# Altium schematic sheet Master.SchDoc(Master)
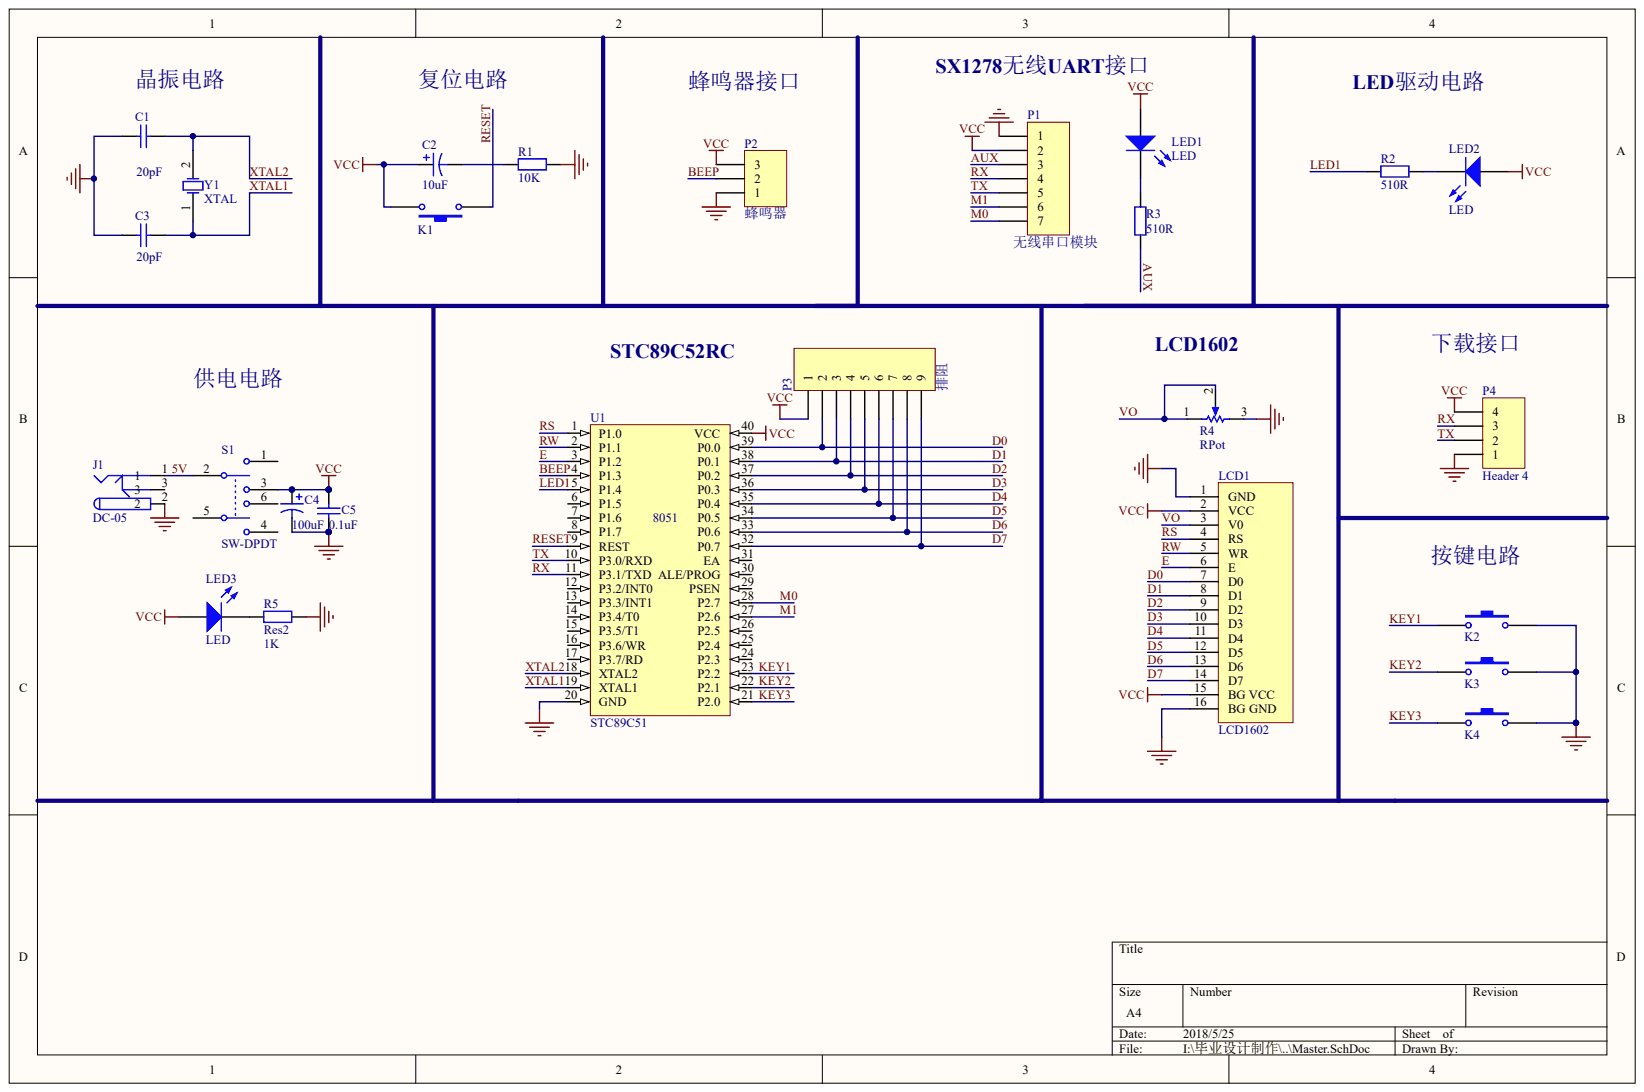
[diagram p0: Master.SchDoc]

## Parts
C1  value 20pF  (hidden comment: Cap)
C2  value 10uF  (hidden comment: Cap Pol1)
C3  value 20pF  (hidden comment: Cap)
C4  value 100uF  (hidden comment: Cap Pol1)
C5  value 0.1uF  (hidden comment: Cap)
J1  DC-05
K1  四脚按键  (value not drawn)
K2  四脚按键  (value not drawn)
K3  四脚按键  (value not drawn)
K4  四脚按键  (value not drawn)
LCD1  LCD1602
LED1  LED
LED2  LED
LED3  LED
P1  无线串口模块
P2  蜂鸣器
P3  排阻
P4  Header 4
R1  value 10K  (hidden comment: Res2)
R2  value 510R  (hidden comment: Res2)
R3  value 510R  (hidden comment: Res2)
R4  RPot
R5  Res2  value 1K
S1  SW-DPDT
U1  STC89C51
Y1  XTAL

## Nets
GND: C1.2 C3.2 C4.2 C5.1 J1.2 K2.1 K3.1 K4.1 LCD1.1(GND) LCD1.16(BG_GND) P1.1 P2.1 P4.1 R1.1 R4.3 R5.2 U1.20(GND)
VCC: C2.1 C4.1 C5.2 K1.1 LCD1.2(VCC) LCD1.15(BG_VCC) LED1.1 LED2.1 LED3.1 P1.2 P2.3 P3.1 P4.4 S1.3 U1.40(VCC)
5V: J1.1 S1.2
AUX: P1.3 R3.1
BEEP: P2.2 U1.4(P1.3)
D0: LCD1.7(D0) P3.2 U1.39(P0.0)
D1: LCD1.8(D1) P3.3 U1.38(P0.1)
D2: LCD1.9(D2) P3.4 U1.37(P0.2)
D3: LCD1.10(D3) P3.5 U1.36(P0.3)
D4: LCD1.11(D4) P3.6 U1.35(P0.4)
D5: LCD1.12(D5) P3.7 U1.34(P0.5)
D6: LCD1.13(D6) P3.8 U1.33(P0.6)
D7: LCD1.14(D7) P3.9 U1.32(P0.7)
E: LCD1.6(E) U1.3(P1.2)
KEY1: K2.2 U1.23(P2.2)
KEY2: K3.2 U1.22(P2.1)
KEY3: K4.2 U1.21(P2.0)
LED1: R2.1 U1.5(P1.4)
M0: P1.7 U1.28(P2.7)
M1: P1.6 U1.27(P2.6)
RESET: K1.2 U1.9(REST)
RS: LCD1.4(RS) U1.1(P1.0)
RW: LCD1.5(WR) U1.2(P1.1)
RX: P1.4 P4.3 U1.11(P3.1/TXD)
TX: P1.5 P4.2 U1.10(P3.0/RXD)
VO: LCD1.3(V0) R4.1 R4.2
XTAL1: C3.1 U1.19(XTAL1) Y1.1
XTAL2: C1.1 U1.18(XTAL2) Y1.2
NetC2_2: C2.2 R1.2
NetLED1_2: LED1.2 R3.2
NetLED2_2: LED2.2 R2.2
NetLED3_2: LED3.2 R5.1

Not connected: J1 3; S1 1 4 5 6; U1 6(P1.5) 7(P1.6) 8(P1.7) 12(P3.2/INT0) 13(P3.3/INT1) 14(P3.4/T0) 15(P3.5/T1) 16(P3.6/WR) 17(P3.7/RD) 24(P2.3) 25(P2.4) 26(P2.5) 29(PSEN) 30(ALE/PROG) 31(EA)

## Library data of the parts
C1-C5 J1 K1-K4 LCD1 LED1-LED3 P1-P4 R1-R5 S1 U1 Y1 = (no symbol name)
  C1 C3 C5: Published: 8-Jun-2000; LatestRevisionDate: 17-Jul-2002; LatestRevisionNote: Re-released for DXP Platform.; PackageReference: RAD-0.3; PackageDescription: Radial Cap, Thru-Hole; 2 Leads; 0.3 in Pin Spacing
  C2 C4: Published: 8-Jun-2000; LatestRevisionDate: 17-Jul-2002; LatestRevisionNote: Re-released for DXP Platform.; PackageReference: RB7.6-15; PackageDescription: Polarized Capacitor (Radial); 2 Leads
  P1-P4: LatestRevisionDate: 17-Jul-2002; LatestRevisionNote: Re-released for DXP Platform.
  R1-R3 R5: Published: 8-Jun-2000; LatestRevisionDate: 17-Jul-2002; LatestRevisionNote: Re-released for DXP Platform.; PackageReference: AXIAL-0.4; PackageDescription: Axial Device, Thru-Hole; 2 Leads; 0.4 in Pin Spacing
  S1: Published: 24-Mar-1999; LatestRevisionDate: 17-Jul-2002; LatestRevisionNote: Re-released for DXP Platform.; PackageReference: DPDT-6
  Y1: Published: 8-Jun-2000; LatestRevisionDate: 17-Jul-2002; LatestRevisionNote: Re-released for DXP Platform.; PackageReference: R38; PackageDescription: Crystal, Thru-Hole; 2 Leads; Body 3.1 x 8.2 mm (Dia.xH)
  C1-C5 P1-P4 R1-R3 R5 S1 Y1: Publisher: Altium Limited
